# Altium schematic sheet Sensors & Communication Schematic.SchDoc("Sensors & Communication Schematic")
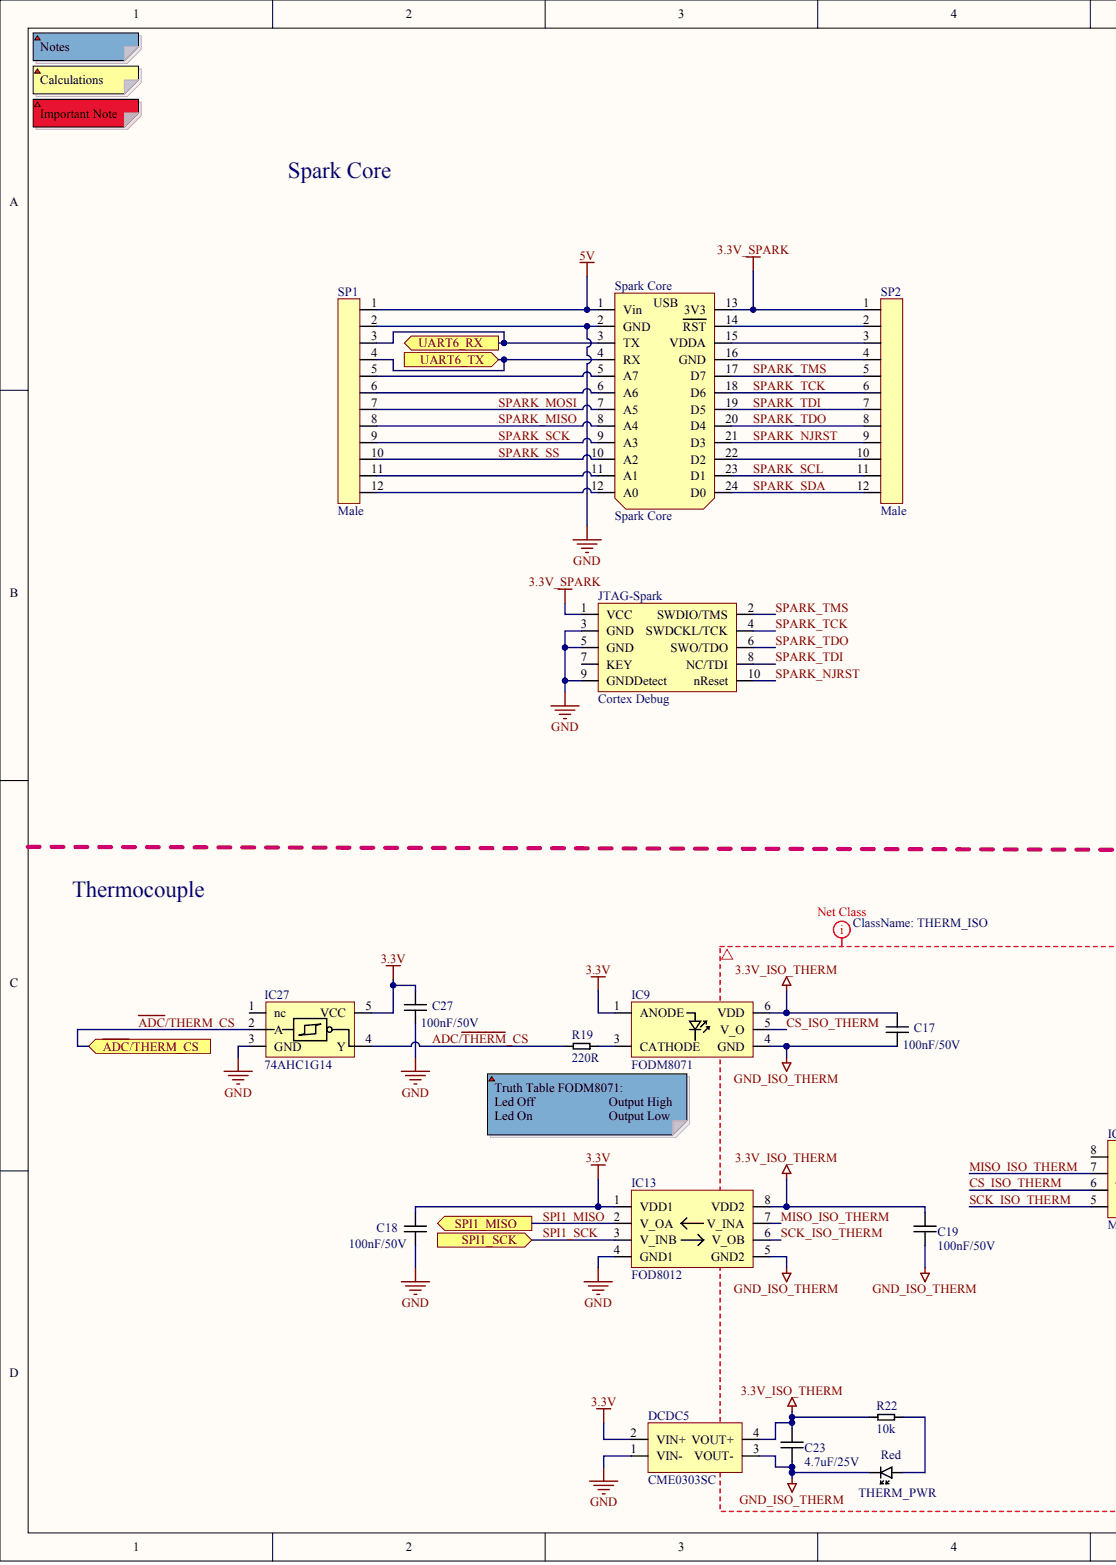
[diagram p0: Sensors & Communication Schematic.SchDoc, left]
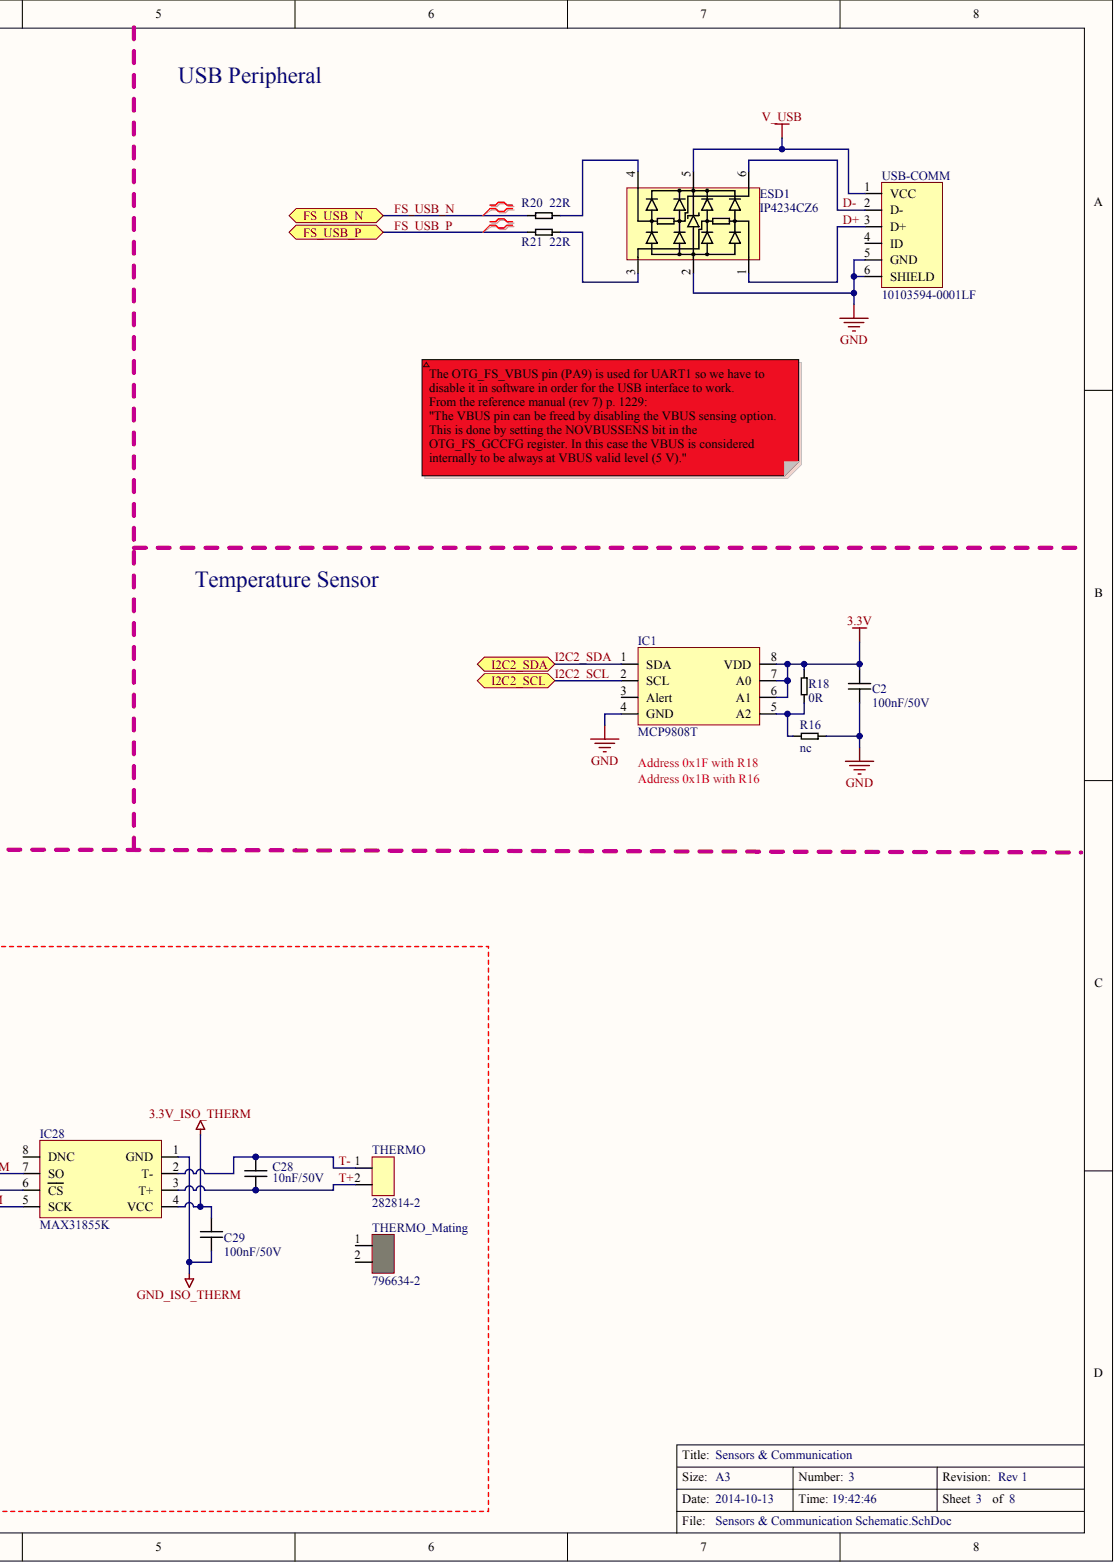
[diagram p1: Sensors & Communication Schematic.SchDoc, right]

## Parts
C2  value 100nF/50V  [0603]  (hidden comment: Cap_0603_100nF/50V)
C17  value 100nF/50V  [0603]  (hidden comment: Cap_0603_100nF/50V)
C18  value 100nF/50V  [0603]  (hidden comment: Cap_0603_100nF/50V)
C19  value 100nF/50V  [0603]  (hidden comment: Cap_0603_100nF/50V)
C23  value 4.7uF/25V  [0805]  (hidden comment: Cap_0805_4.7uF/25V)
C27  value 100nF/50V  [0603]  (hidden comment: Cap_0603_100nF/50V)
C28  value 10nF/50V  [0603]  (hidden comment: Cap_0603_10nF/50V)
C29  value 100nF/50V  [0603]  (hidden comment: Cap_0603_100nF/50V)
DCDC5  CME0303SC  [4-SIP]
ESD1  IP4234CZ6
IC1  MCP9808T
IC9  FODM8071
IC13  FOD8012
IC27  74AHC1G14  [TSSOP-5]
IC28  MAX31855K
JTAG-Spark  value Cortex Debug  (hidden comment: Connector 2x5 Cortex Debug)
R16  value nc  [0603]  (hidden comment: Res_0603)
R18  value 0R  [0603]  (hidden comment: Res_0603_0R)
R19  value 220R  [0603]  (hidden comment: Res_0603_220R)
R20  value 22R  [0603]  (hidden comment: Res_0603_22R)
R21  value 22R  [0603]  (hidden comment: Res_0603_22R)
R22  value 10k  [0603]  (hidden comment: Res_0603_10k)
SP1  value Male  (hidden comment: Connector 1x12)
SP2  value Male  (hidden comment: Connector 1x12)
Spark_Core  Spark Core
THERM_PWR  value Red  (hidden comment: LED_0603_Red)
THERMO  value 282814-2  Part No.: 282814-2  (hidden comment: Terminal Block 1x2 5.08mm)
THERMO_Mating  value 796634-2  Part No.: 796634-2  (hidden comment: Terminal Block 1x2 5.08mm Mating Connector)
USB-COMM  value 10103594-0001LF  (hidden comment: USB Micro 10103594-0001LF)

## Ports
FS_USB_N, FS_USB_P, I2C2_SCL, I2C2_SDA, SPI1_MISO, SPI1_SCK, UART6_RX, UART6_TX, ~{ADC}/THERM_CS

## Nets
3.3V: C2.2 C18.2 C27.2 DCDC5.2(VIN+) IC1.6(A1) IC1.7(A0) IC1.8(VDD) IC9.1(ANODE) IC13.1(VDD1) IC27.5(VCC) R18.2
3.3V_ISO_THERM: C17.2 C19.2 C23.2 C29.2 DCDC5.4(VOUT+) IC9.6(VDD) IC13.8(VDD2) IC28.4(VCC) R22.2
3.3V_SPARK: JTAG-Spark.1(VCC) SP2.1 Spark_Core.13(3V3)
5V: SP1.1 Spark_Core.1(Vin)
GND: C2.1 C18.1 C27.1 DCDC5.1(VIN-) ESD1.2 IC1.4(GND) IC13.4(GND1) IC27.3(GND) JTAG-Spark.3(GND) JTAG-Spark.5(GND) JTAG-Spark.9(GNDDetect) R16.2 SP1.2 Spark_Core.2(GND) USB-COMM.5(GND) USB-COMM.6(SHIELD)
GND_ISO_THERM: C17.1 C19.1 C23.1 C29.1 DCDC5.3(VOUT-) IC9.4(GND) IC13.5(GND2) IC28.1(GND) THERM_PWR.CATHODE
V_USB: ESD1.5 USB-COMM.1(VCC)
~{ADC}/THERM_CS: IC27.2(A) ; port ~{ADC}/THERM_CS
ADC/~{THERM}_CS: IC27.4(Y) R19.1
CS_ISO_THERM: IC9.5(V_O) IC28.6(CS)
D+: ESD1.1 USB-COMM.3(D+)
D-: ESD1.6 USB-COMM.2(D-)
FS_USB_N: R20.1 ; port FS_USB_N
FS_USB_P: R21.1 ; port FS_USB_P
I2C2_SCL: IC1.2(SCL) ; port I2C2_SCL
I2C2_SDA: IC1.1(SDA) ; port I2C2_SDA
MISO_ISO_THERM: IC13.7(V_INA) IC28.7(SO)
SCK_ISO_THERM: IC13.6(V_OB) IC28.5(SCK)
SPARK_MISO: SP1.8 Spark_Core.8(A4)
SPARK_MOSI: SP1.7 Spark_Core.7(A5)
SPARK_NJRST: JTAG-Spark.10(nReset) SP2.9 Spark_Core.21(D3)
SPARK_SCK: SP1.9 Spark_Core.9(A3)
SPARK_SCL: SP2.11 Spark_Core.23(D1)
SPARK_SDA: SP2.12 Spark_Core.24(D0)
SPARK_SS: SP1.10 Spark_Core.10(A2)
SPARK_TCK: JTAG-Spark.4(SWDCKL/TCK) SP2.6 Spark_Core.18(D6)
SPARK_TDI: JTAG-Spark.8(NC/TDI) SP2.7 Spark_Core.19(D5)
SPARK_TDO: JTAG-Spark.6(SWO/TDO) SP2.8 Spark_Core.20(D4)
SPARK_TMS: JTAG-Spark.2(SWDIO/TMS) SP2.5 Spark_Core.17(D7)
SPI1_MISO: IC13.2(V_OA) ; port SPI1_MISO
SPI1_SCK: IC13.3(V_INB) ; port SPI1_SCK
T+: C28.1 IC28.3(T+) THERMO.2
T-: C28.2 IC28.2(T-) THERMO.1
UART6_RX: SP1.3 Spark_Core.3(TX) ; port UART6_RX
UART6_TX: SP1.4 Spark_Core.4(RX) ; port UART6_TX
NetESD1_3: ESD1.3 R21.2
NetESD1_4: ESD1.4 R20.2
NetIC1_5: IC1.5(A2) R16.1 R18.1
NetIC9_3: IC9.3(CATHODE) R19.2
NetR22_1: R22.1 THERM_PWR.ANODE
NetSP1_5: SP1.5 Spark_Core.5(A7)
NetSP1_6: SP1.6 Spark_Core.6(A6)
NetSP1_11: SP1.11 Spark_Core.11(A1)
NetSP1_12: SP1.12 Spark_Core.12(A0)
NetSP2_2: SP2.2 Spark_Core.14(RST)
NetSP2_3: SP2.3 Spark_Core.15(VDDA)
NetSP2_4: SP2.4 Spark_Core.16(GND)
NetSP2_10: SP2.10 Spark_Core.22(D2)
N00237:

Not connected: IC1 3(Alert); IC27 1(nc); IC28 8(DNC); JTAG-Spark 7(KEY); THERMO_Mating 1 2; USB-COMM 4(ID)

## Library data of the parts
DCDC5 = (no symbol name): Part No.: CME0303S3C; Manufacturer: Murata; DigiKey: 811-2889-5-ND; Price SEK (No VAT): 28; Mouser: 580-CME0303S3C; Datasheet: http://www.murata-ps.com/data/power/ncl/kdc_cme.pdf
ESD1 = (no symbol name): Manufacturer: NXP; Part No.: IP4234CZ6,125; Mouser: 771-IP4234CZ6125; DigiKey: 568-5869-1-ND; Price SEK (No VAT): 3; Value: IP4234CZ6
IC1 = (no symbol name): Part No.: MCP9808T-E/MS; Manufacturer: Microchip; DigiKey: MCP9808-E/MS-ND; Datasheet: http://www.microchip.com/mymicrochip/filehandler.aspx?ddocname=en556196; Mouser: 579-MCP9808T-E/MS; Price SEK (No VAT): 9
IC9 = (no symbol name): Manufacturer: Fairchild Semiconductor; DigiKey: FODM8071-ND; Part No.: FODM8071; Price SEK (No VAT): 14; Datasheet: http://www.fairchildsemi.com/ds/FO/FODM8071.pdf; Mouser: 512-FODM8071; Value: FODM8071
IC13 = (no symbol name): Manufacturer: Fairchild Semiconductor; Note: Should be able to replace ADUM1201 as pin-compatible; DigiKey: FOD8012-ND; Part No.: FOD8012; Price SEK (No VAT): 31; Datasheet: http://www.fairchildsemi.com/ds/FO/FOD8012.pdf; Mouser: 512-FOD8012; Value: FOD8012
IC27 = (no symbol name): Part No.: 74AHC1G14GW,125; Manufacturer: NXP; DigiKey: 568-2502-1-ND; Price SEK (No VAT): 3; Mouser: 771-74AHC1G14GW-G; Value: 74AHC1G14
IC28 = (no symbol name): Part No.: MAX31855KASA+; Manufacturer: Maxim; DigiKey: MAX31855KASA+TCT-ND; Price SEK (No VAT): 33; Datasheet: http://datasheets.maximintegrated.com/en/ds/MAX31855.pdf; Mouser: 700-MAX31855KASA+; Value: MAX31855K
Spark_Core = (no symbol name): Female Headers 1x12: eBay; Spark Core Website: https://www.spark.io/; Price SEK (No VAT): 280; Value: Spark Core
THERMO = (no symbol name): Manufacturer: TE Connectivity; Mating Connector: 796634-2; RS: 710-0393 (10-pack); Mouser: 571-282814-2; DigiKey: A98065-ND; Elfa: *; Price SEK (No VAT): 4
THERMO_Mating = (no symbol name): Manufacturer: TE Connectivity; RS: 361-7320 (5-pack); Mouser: 571-7966342; DigiKey: A98223-ND; Elfa: *; Price SEK (No VAT): 10; Farnell: *
USB-COMM = (no symbol name): Manufacturer: FCI; RS: 714-2347; Mouser: 649-10103594-0001LF; DigiKey: 609-4050-1-ND; Farnell: 2293752; Price SEK (No VAT): 5; Part No.: 10103594-0001LF
C2 C17-C19 C23 C27-C29 JTAG-Spark R16 R18-R22 SP1 SP2 THERM_PWR = (no symbol name)
  R16 R18-R22: Price (No VAT): 0,1
